# Altium schematic sheet PAGE05 FPGA Config.SchDoc
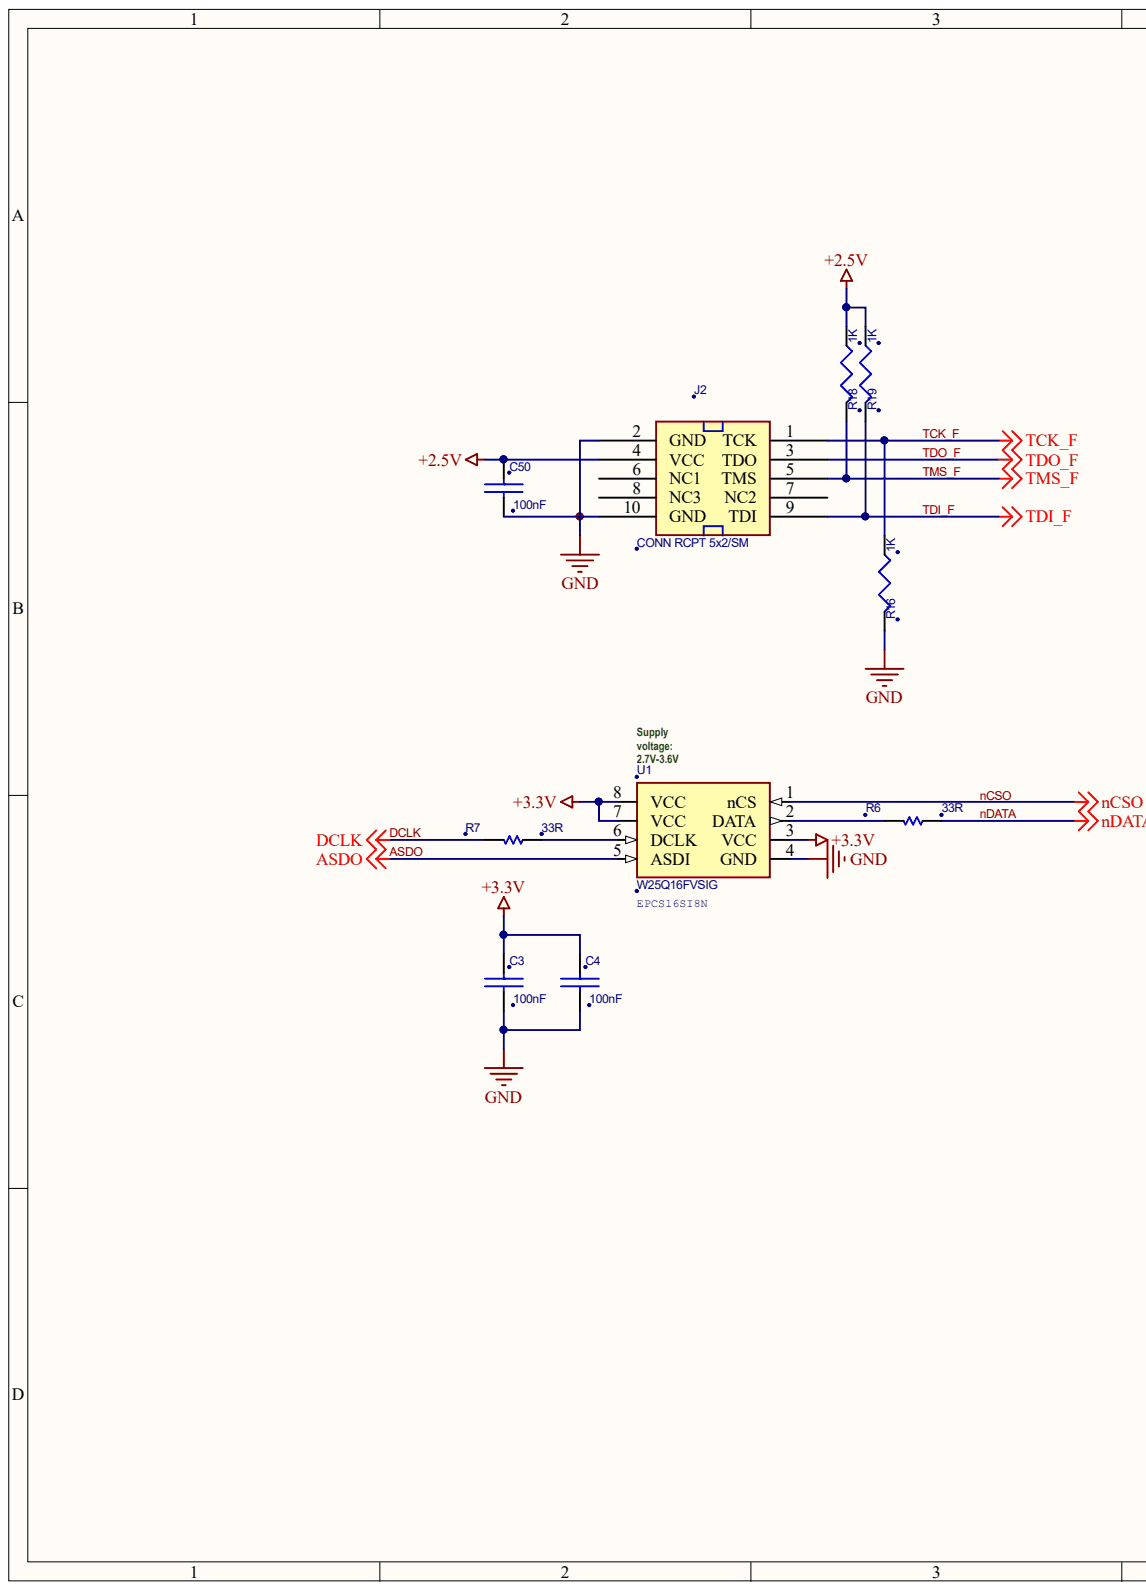
[diagram p0: PAGE05 FPGA Config.SchDoc, left]
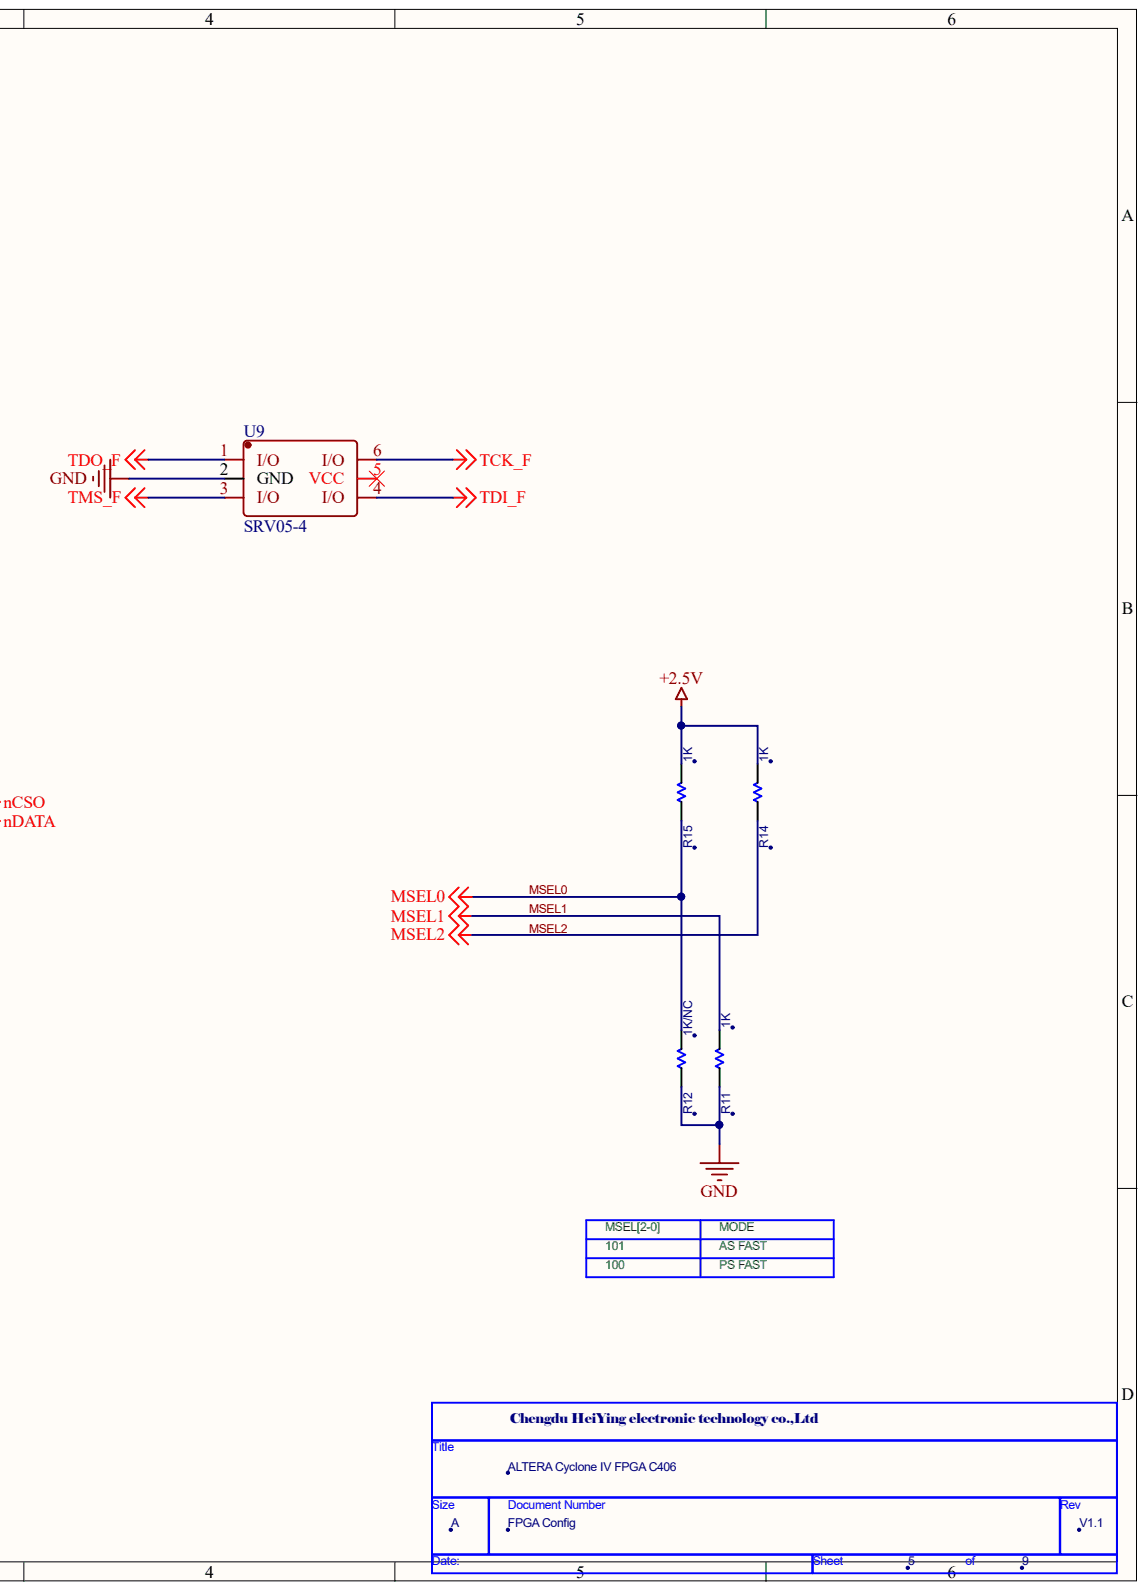
[diagram p1: PAGE05 FPGA Config.SchDoc, right]

## Parts
C3  100nF  [C0402]
C4  100nF  [C0402]
C50  100nF  [C0402]
J2  CONN RCPT 5x2/SM  [CONN-SMD_3110-10RG0BK00A1]
R6  33R  [R0402]
R7  33R  [R0402]
R11  1K  [R0402]
R12  1K/NC  [R0402]
R14  1K  [R0402]
R15  1K  [R0402]
R16  1K  [R0402]
R18  1K  [R0402]
R19  1K  [R0402]
U1  W25Q16FVSIG  [SOIC8_1P27_208MIL]
U9  SRV05-4  [SOT23-6]

## Nets
+2.5V: C50.1 J2.4(VCC) R14.2 R15.2 R18.1 R19.1
+3.3V: C3.1 C4.1 U1.3(VCC) U1.7(VCC) U1.8(VCC)
GND: C3.2 C4.2 C50.2 J2.2(GND) J2.10(GND) R11.1 R12.1 R16.2 U1.4(GND) U9.2(GND)
ASDO: U1.5(ASDI)
DCLK: R7.2
MSEL0: R12.2 R15.1
MSEL1: R11.2
MSEL2: R14.1
NCSO: U1.1(nCS)
NDATA: R6.1
TCK_F: J2.1(TCK) R16.1 U9.6(I/O)
TDI_F: J2.9(TDI) R19.2 U9.4(I/O)
TDO_F: J2.3(TDO) U9.1(I/O)
TMS_F: J2.5(TMS) R18.2 U9.3(I/O)
NetR6_2: R6.2 U1.2(DATA)
NetR7_1: R7.1 U1.6(DCLK)

Not connected: J2 6(NC1) 7(NC2) 8(NC3); U9 5(VCC)

## Library data of the parts
C3 C4 C50 = CAP_0: CAP CER .1UF 16V X7R 0402; MANUFACTURER: MURATA; MANUFACTURER P/N: GRM155R71C104KA88D; ROOM: PHY&FPGA; Component Kind: Standard; DIELECTRIC: X7R; DISTRIBUTOR: DIGIKEY; DISTRIBUTOR P/N: 490-3261-1-ND; PRICE: 0.00300
J2 = CONN RCPT 5x2/SM_0: Component Kind: Standard
R6 R7 R11 R12 R14 R15 = SMALL R1: Component Kind: Standard
R16 R18 R19 = R_6: Component Kind: Standard
U1 = EPCS1SI8N_0: Component Kind: Standard
U9 = SRV05-4: Component Kind: Standard; Library Name: 未分类IC.IntLib
